# Altium schematic sheet PLD_Connectors.SchDoc
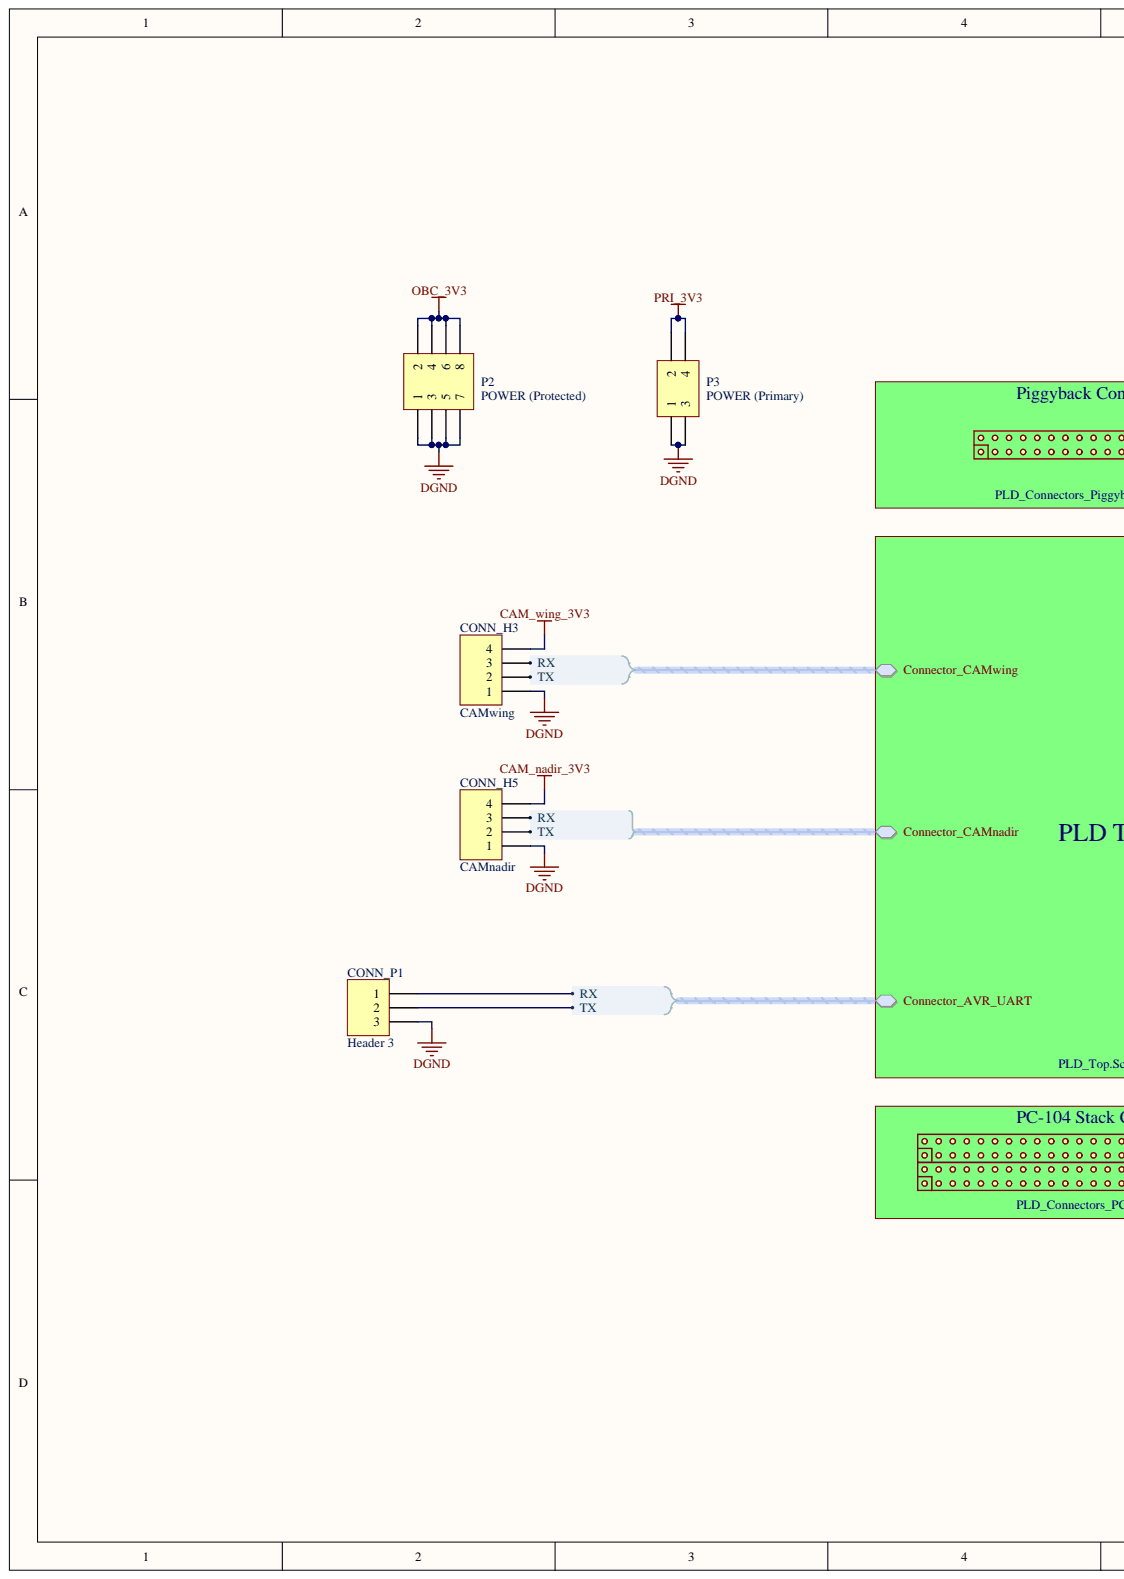
[diagram p0: PLD_Connectors.SchDoc, left]
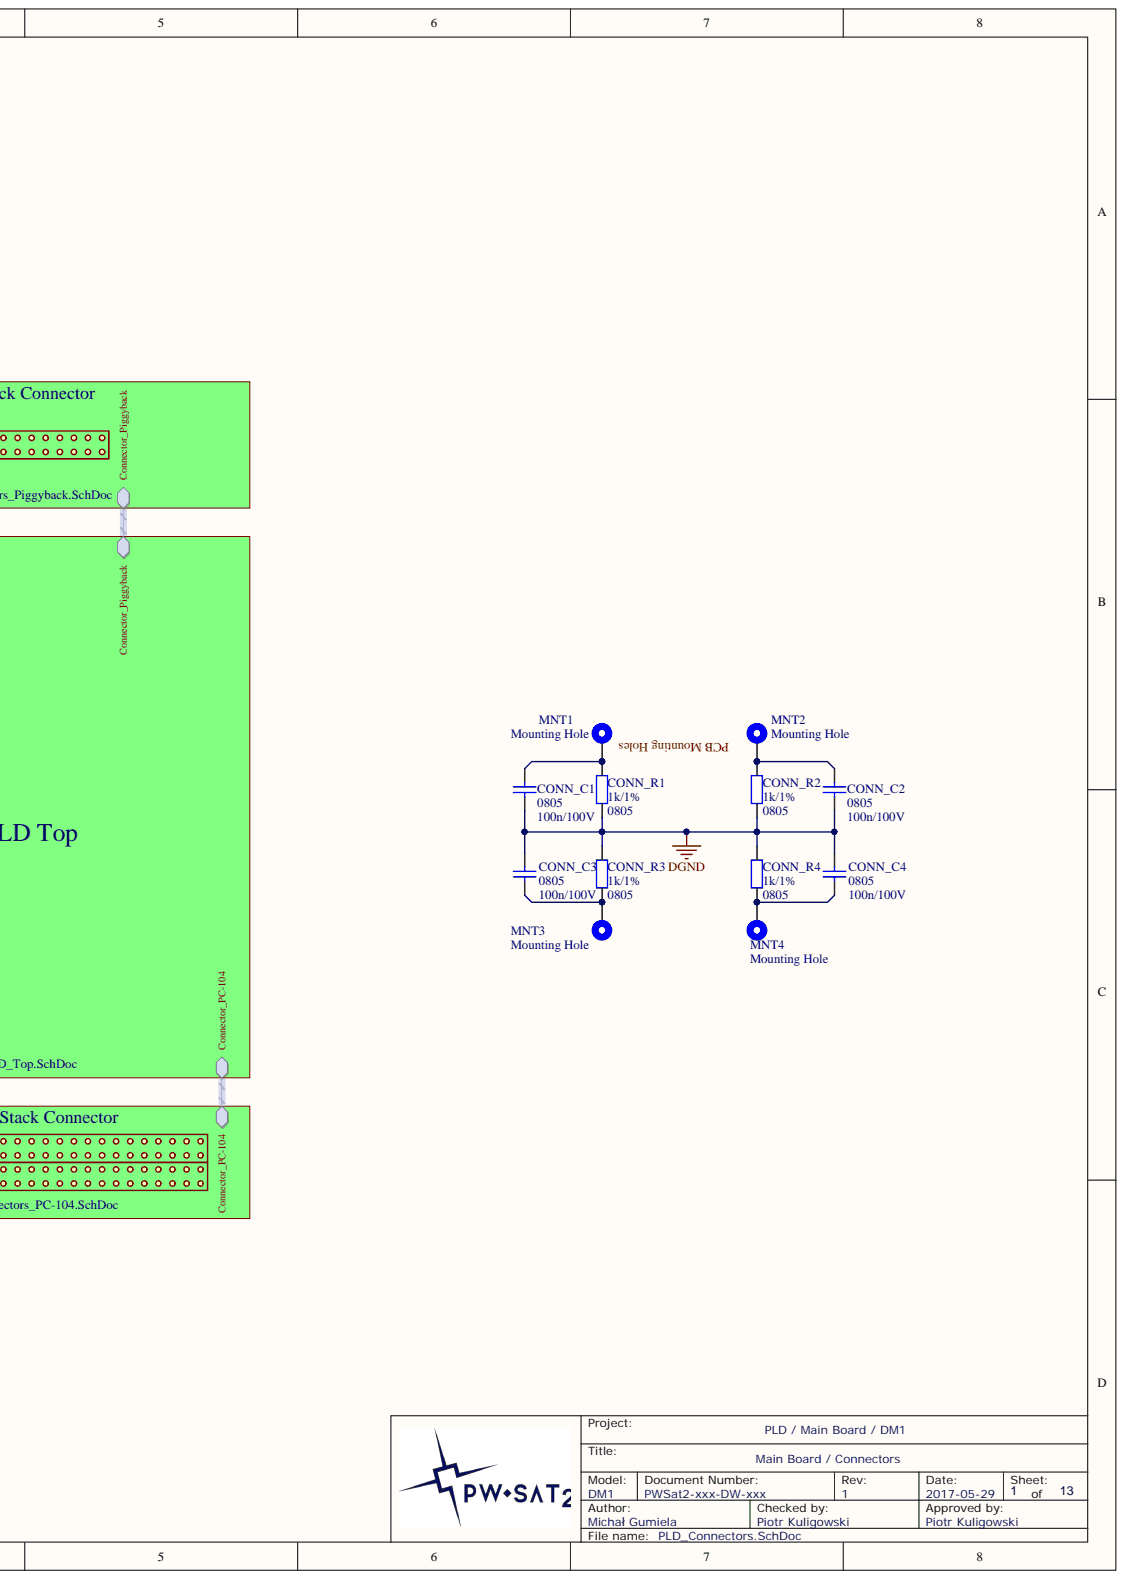
[diagram p1: PLD_Connectors.SchDoc, right]

## Parts
CONN_C1  100n/100V  Package: 0805  [SMD_Capacitor_0805]
CONN_C2  100n/100V  Package: 0805  [SMD_Capacitor_0805]
CONN_C3  100n/100V  Package: 0805  [SMD_Capacitor_0805]
CONN_C4  100n/100V  Package: 0805  [SMD_Capacitor_0805]
CONN_H3  CAMwing  [HEADER 1X4H Male]
CONN_H5  CAMnadir  [HEADER 1X4H Male]
CONN_P1  Header 3  [HEADER1X3H Male]
CONN_R1  1k/1%  Package: 0805  [SMD_Resistor_0805]
CONN_R2  1k/1%  Package: 0805  [SMD_Resistor_0805]
CONN_R3  1k/1%  Package: 0805  [SMD_Resistor_0805]
CONN_R4  1k/1%  Package: 0805  [SMD_Resistor_0805]
MNT1  Mounting Hole  [PC-104_PcbMntHole_M3]
MNT2  Mounting Hole  [PC-104_PcbMntHole_M3]
MNT3  Mounting Hole  [PC-104_PcbMntHole_M3]
MNT4  Mounting Hole  [PC-104_PcbMntHole_M3]
P2  POWER (Protected)  [HEADER 2X4 MALE]
P3  POWER (Primary)  [HDR2X2]

## Nets
CAM_nadir_3V3: CONN_H5.4
CAM_wing_3V3: CONN_H3.4
DGND: CONN_C1.1 CONN_C2.1 CONN_C3.2 CONN_C4.2 CONN_H3.1 CONN_H5.1 CONN_P1.3 CONN_R1.1 CONN_R2.1 CONN_R3.2 CONN_R4.2 P2.1 P2.3 P2.5 P2.7 P3.1 P3.3
OBC_3V3: P2.2 P2.4 P2.6 P2.8
PRI_3V3: P3.2 P3.4
NetCONN_C1_2: CONN_C1.2 CONN_R1.2 MNT1.1
NetCONN_C2_2: CONN_C2.2 CONN_R2.2 MNT2.1
NetCONN_C3_1: CONN_C3.1 CONN_R3.1 MNT3.1
NetCONN_C4_1: CONN_C4.1 CONN_R4.1 MNT4.1
NetCONN_H3_2: CONN_H3.2
NetCONN_H3_3: CONN_H3.3
NetCONN_H5_2: CONN_H5.2
NetCONN_H5_3: CONN_H5.3
NetCONN_P1_1: CONN_P1.1
NetCONN_P1_2: CONN_P1.2
Connector_PC-104.3V3:
Connector_PC-104.I2C_Payload.SCL:
Connector_PC-104.I2C_Payload.SDA:
Connector_Piggyback.GPIO.CAM_SELECT:
Connector_Piggyback.GPIO.FLASH1_~{CS}:
Connector_Piggyback.GPIO.FLASH2_~{CS}:
Connector_Piggyback.GPIO.FLASH3_~{CS}:
Connector_Piggyback.GPIO.FRAM1_~{CS}:
Connector_Piggyback.GPIO.FRAM2_~{CS}:
Connector_Piggyback.GPIO.FRAM3_~{CS}:
Connector_Piggyback.GPIO.LED_PE4:
Connector_Piggyback.GPIO.PLD_INT:
Connector_Piggyback.GPIO.SAIL_INDICATOR:
Connector_Piggyback.SPI.MISO:
Connector_Piggyback.SPI.MOSI:
Connector_Piggyback.SPI.SCK:
Connector_Piggyback.UART.RX:
Connector_Piggyback.UART.TX:

## Library data of the parts
CONN_C1-CONN_C4 = Cer_C0805T104K1RCLTU: Ceramic Cap Kemet COTS 100n/100V X7R 0805; Component Kind: Standard; Library Name: capacitors.IntLib; Manufacturer: Kemet; ManufacturerPartNumber: C0805T104K1RCLTU; Value: 100n/100V
CONN_H3 CONN_H5 = Header 4: Header, 4-Pin; Component Kind: Standard; LatestRevisionDate: 17-Jul-2002; LatestRevisionNote: Re-released for DXP Platform.; Library Name: connectors_printed-circuit-board.IntLib; Publisher: Altium Limited
CONN_P1 = Header 3: Header, 3-Pin; Component Kind: Standard; LatestRevisionDate: 17-Jul-2002; LatestRevisionNote: Re-released for DXP Platform.; Library Name: connectors_printed-circuit-board.IntLib; Publisher: Altium Limited
CONN_R1-CONN_R4 = CRCW08051K00FKxxxx: 1k/1% Vishay CRCW 0805 Resistor. 125mW, 100ppm, 150V.; Component Kind: Standard; Library Name: resistors.IntLib; Manufacturer: Vishay; ManufacturerPartNumber: CRCW08051K00FKxxxx; Value: 1k/1%
MNT1-MNT4 = MntHole: PCB Mounting Hole; Component Kind: Standard; Value: Mounting Hole; Library Name: connectors_printed-circuit-board.IntLib
P2 = Header 4X2: Header, 4-Pin, Dual row; Component Kind: Standard; LatestRevisionDate: 17-Jul-2002; LatestRevisionNote: Re-released for DXP Platform.; Library Name: connectors_printed-circuit-board.IntLib; Publisher: Altium Limited
P3 = Header 2X2: Header, 2-Pin, Dual row; Component Kind: Standard; LatestRevisionDate: 17-Jul-2002; LatestRevisionNote: Re-released for DXP Platform.; Library Name: connectors_printed-circuit-board.IntLib; Publisher: Altium Limited
